# Altium schematic sheet DDR3.SchDoc
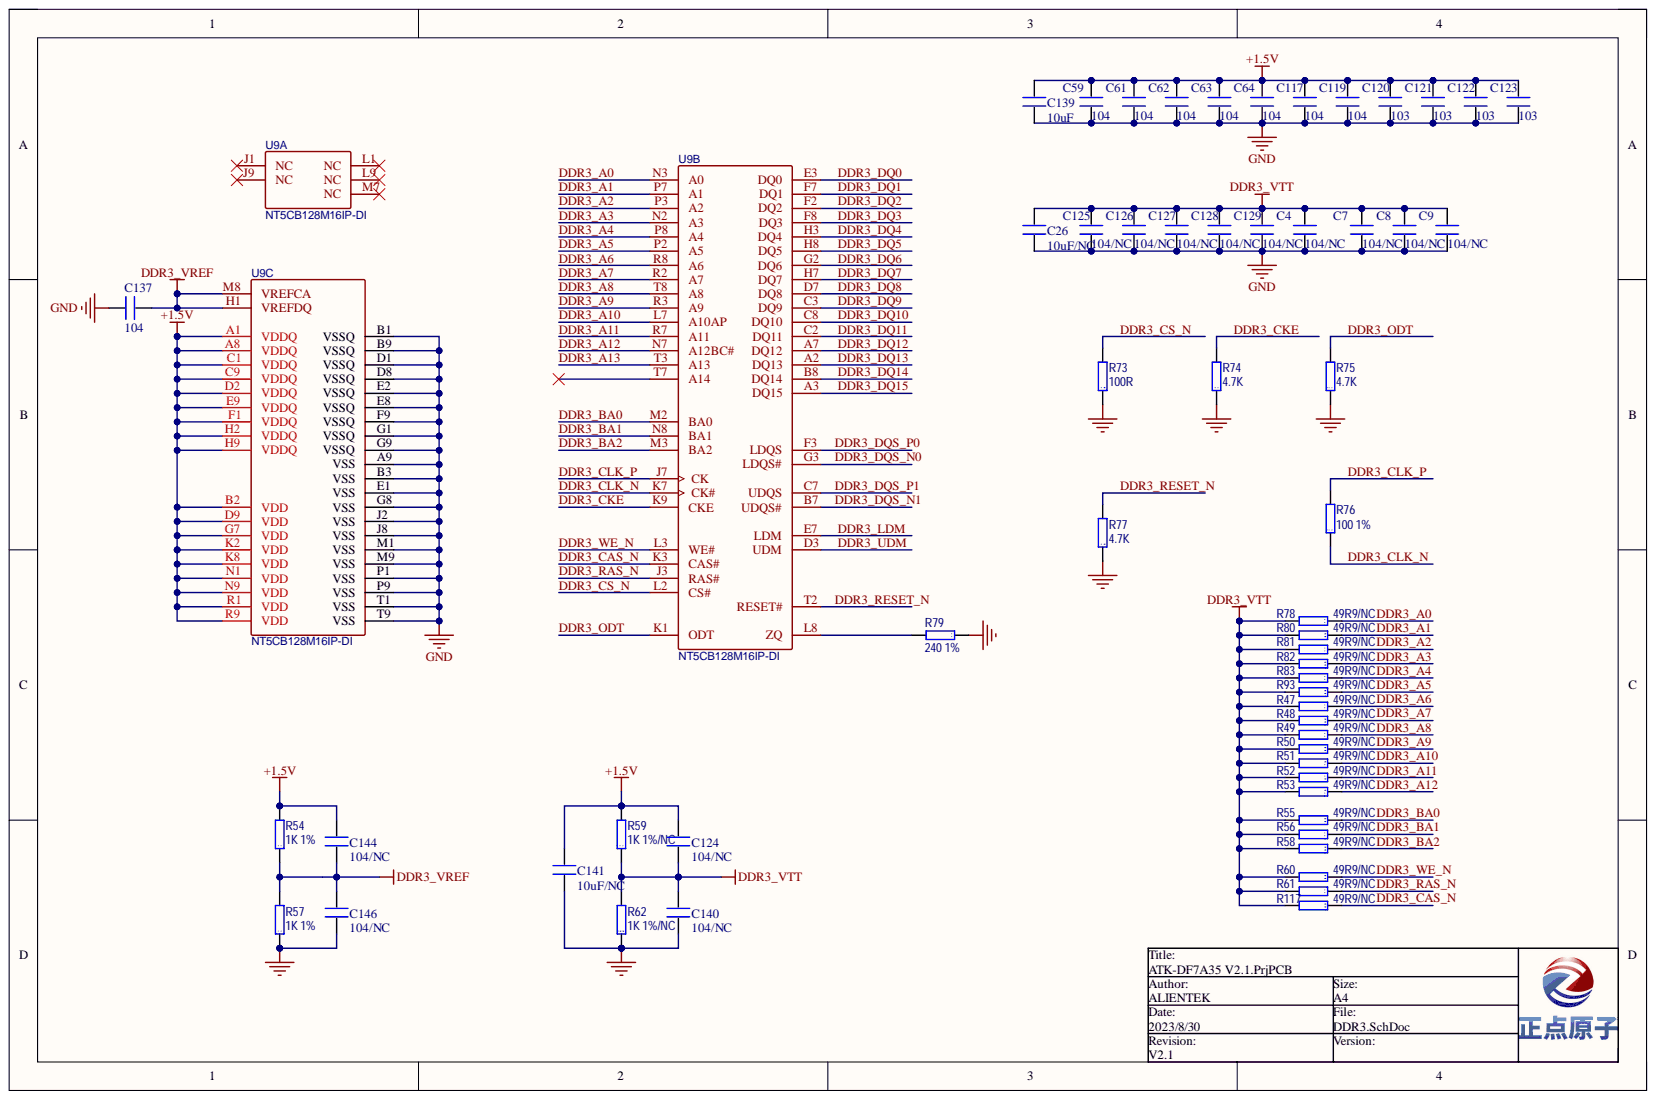
[diagram p0: DDR3.SchDoc]

## Parts
C4  104/NC  [0603P]
C7  104/NC  [0603P]
C8  104/NC  [0603P]
C9  104/NC  [0603P]
C26  10uF/NC  [0603P]
C59  104  [0603P]
C61  104  [0603P]
C62  104  [0603P]
C63  104  [0603P]
C64  104  [0603P]
C117  104  [0603P]
C119  104  [0603P]
C120  103  [0603P]
C121  103  [0603P]
C122  103  [0603P]
C123  103  [0603P]
C124  104/NC  [0603P]
C125  104/NC  [0603P]
C126  104/NC  [0603P]
C127  104/NC  [0603P]
C128  104/NC  [0603P]
C129  104/NC  [0603P]
C137  104  [0603P]
C139  10uF  [0603P]
C140  104/NC  [0603P]
C141  10uF/NC  [0603P]
C144  104/NC  [0603P]
C146  104/NC  [0603P]
R47  49R9/NC  [0603P]
R48  49R9/NC  [0603P]
R49  49R9/NC  [0603P]
R50  49R9/NC  [0603P]
R51  49R9/NC  [0603P]
R52  49R9/NC  [0603P]
R53  49R9/NC  [0603P]
R54  1K 1%  [0603P]
R55  49R9/NC  [0603P]
R56  49R9/NC  [0603P]
R57  1K 1%  [0603P]
R58  49R9/NC  [0603P]
R59  1K 1%/NC  [0603P]
R60  49R9/NC  [0603P]
R61  49R9/NC  [0603P]
R62  1K 1%/NC  [0603P]
R73  100R  [0603P]
R74  4.7K  [0603P]
R75  4.7K  [0603P]
R76  100 1%  [0603P]
R77  4.7K  [0603P]
R78  49R9/NC  [0603P]
R79  240 1%  [0603P]
R80  49R9/NC  [0603P]
R81  49R9/NC  [0603P]
R82  49R9/NC  [0603P]
R83  49R9/NC  [0603P]
R93  49R9/NC  [0603P]
R117  49R9/NC  [0603P]
U9  NT5CB128M16IP-DI  [FBGA-96_DDR3]  (parts A,B,C)

## Nets
+1.5V: C59.2 C61.2 C62.2 C63.2 C64.2 C117.2 C119.2 C120.2 C121.2 C122.2 C123.2 C124.1 C139.1 C141.1 C144.1 R54.2 R59.2 U9.A1(VDDQ) U9.A8(VDDQ) U9.B2(VDD) U9.C1(VDDQ) U9.C9(VDDQ) U9.D2(VDDQ) U9.D9(VDD) U9.E9(VDDQ) U9.F1(VDDQ) U9.G7(VDD) U9.H2(VDDQ) U9.H9(VDDQ) U9.K2(VDD) U9.K8(VDD) U9.N1(VDD) U9.N9(VDD) U9.R1(VDD) U9.R9(VDD)
DDR3_VREF: C137.2 C144.2 C146.1 R54.1 R57.2 U9.H1(VREFDQ) U9.M8(VREFCA)
DDR3_VTT: C4.2 C7.2 C8.2 C9.2 C26.1 C124.2 C125.2 C126.2 C127.2 C128.2 C129.2 C140.1 R47.2 R48.2 R49.2 R50.2 R51.2 R52.2 R53.2 R55.2 R56.2 R58.2 R59.1 R60.2 R61.2 R62.2 R78.2 R80.2 R81.2 R82.2 R83.2 R93.2 R117.2
GND: C4.1 C7.1 C8.1 C9.1 C26.2 C59.1 C61.1 C62.1 C63.1 C64.1 C117.1 C119.1 C120.1 C121.1 C122.1 C123.1 C125.1 C126.1 C127.1 C128.1 C129.1 C137.1 C139.2 C140.2 C141.2 C146.2 R57.1 R62.1 R73.1 R74.1 R75.1 R77.1 R79.1 U9.A9(VSS) U9.B1(VSSQ) U9.B3(VSS) U9.B9(VSSQ) U9.D1(VSSQ) U9.D8(VSSQ) U9.E1(VSS) U9.E2(VSSQ) U9.E8(VSSQ) U9.F9(VSSQ) U9.G1(VSSQ) U9.G8(VSS) U9.G9(VSSQ) U9.J2(VSS) U9.J8(VSS) U9.M1(VSS) U9.M9(VSS) U9.P1(VSS) U9.P9(VSS) U9.T1(VSS) U9.T9(VSS)
DDR3_A0: R78.1 U9.N3(A0)
DDR3_A1: R80.1 U9.P7(A1)
DDR3_A2: R81.1 U9.P3(A2)
DDR3_A3: R82.1 U9.N2(A3)
DDR3_A4: R83.1 U9.P8(A4)
DDR3_A5: R93.1 U9.P2(A5)
DDR3_A6: R47.1 U9.R8(A6)
DDR3_A7: R48.1 U9.R2(A7)
DDR3_A8: R49.1 U9.T8(A8)
DDR3_A9: R50.1 U9.R3(A9)
DDR3_A10: R51.1 U9.L7(A10AP)
DDR3_A11: R52.1 U9.R7(A11)
DDR3_A12: R53.1 U9.N7(A12BC#)
DDR3_A13: U9.T3(A13)
DDR3_BA0: R55.1 U9.M2(BA0)
DDR3_BA1: R56.1 U9.N8(BA1)
DDR3_BA2: R58.1 U9.M3(BA2)
DDR3_CAS_N: R117.1 U9.K3(CAS#)
DDR3_CKE: R74.2 U9.K9(CKE)
DDR3_CLK_N: R76.1 U9.K7(CK#)
DDR3_CLK_P: R76.2 U9.J7(CK)
DDR3_CS_N: R73.2 U9.L2(CS#)
DDR3_DQ0: U9.E3(DQ0)
DDR3_DQ1: U9.F7(DQ1)
DDR3_DQ2: U9.F2(DQ2)
DDR3_DQ3: U9.F8(DQ3)
DDR3_DQ4: U9.H3(DQ4)
DDR3_DQ5: U9.H8(DQ5)
DDR3_DQ6: U9.G2(DQ6)
DDR3_DQ7: U9.H7(DQ7)
DDR3_DQ8: U9.D7(DQ8)
DDR3_DQ9: U9.C3(DQ9)
DDR3_DQ10: U9.C8(DQ10)
DDR3_DQ11: U9.C2(DQ11)
DDR3_DQ12: U9.A7(DQ12)
DDR3_DQ13: U9.A2(DQ13)
DDR3_DQ14: U9.B8(DQ14)
DDR3_DQ15: U9.A3(DQ15)
DDR3_DQS_N0: U9.G3(LDQS#)
DDR3_DQS_N1: U9.B7(UDQS#)
DDR3_DQS_P0: U9.F3(LDQS)
DDR3_DQS_P1: U9.C7(UDQS)
DDR3_LDM: U9.E7(LDM)
DDR3_ODT: R75.2 U9.K1(ODT)
DDR3_RAS_N: R61.1 U9.J3(RAS#)
DDR3_RESET_N: R77.2 U9.T2(RESET#)
DDR3_UDM: U9.D3(UDM)
DDR3_WE_N: R60.1 U9.L3(WE#)
NetR79_2: R79.2 U9.L8(ZQ)

Not connected: U9 J1(NC) J9(NC) L1(NC) L9(NC) M7(NC) T7(A14)

## Library data of the parts
C4 C7-C9 C26 C59 C61-C64 C117 C119-C129 C137 C139-C141 C144 C146 = C: Component Kind: Standard; Library Name: MYCOMLIB.IntLib
R47-R62 R73-R83 R93 R117 = RES: Component Kind: Standard; Library Name: ZYNQ.SCHLIB
U9 = MT41J256M16RE-15E_1: BOM_Manufacturer Part: SHOWNAME=F; subpart_no: 2; Component Kind: Standard; Library Name: ZYNQ.SCHLIB; spicePre: U; spiceSymbolName: MT41J256M16RE-15E
